# Altium schematic sheet 01_USB_B_and_Power.SchDoc(01_USB_B_and_Power)
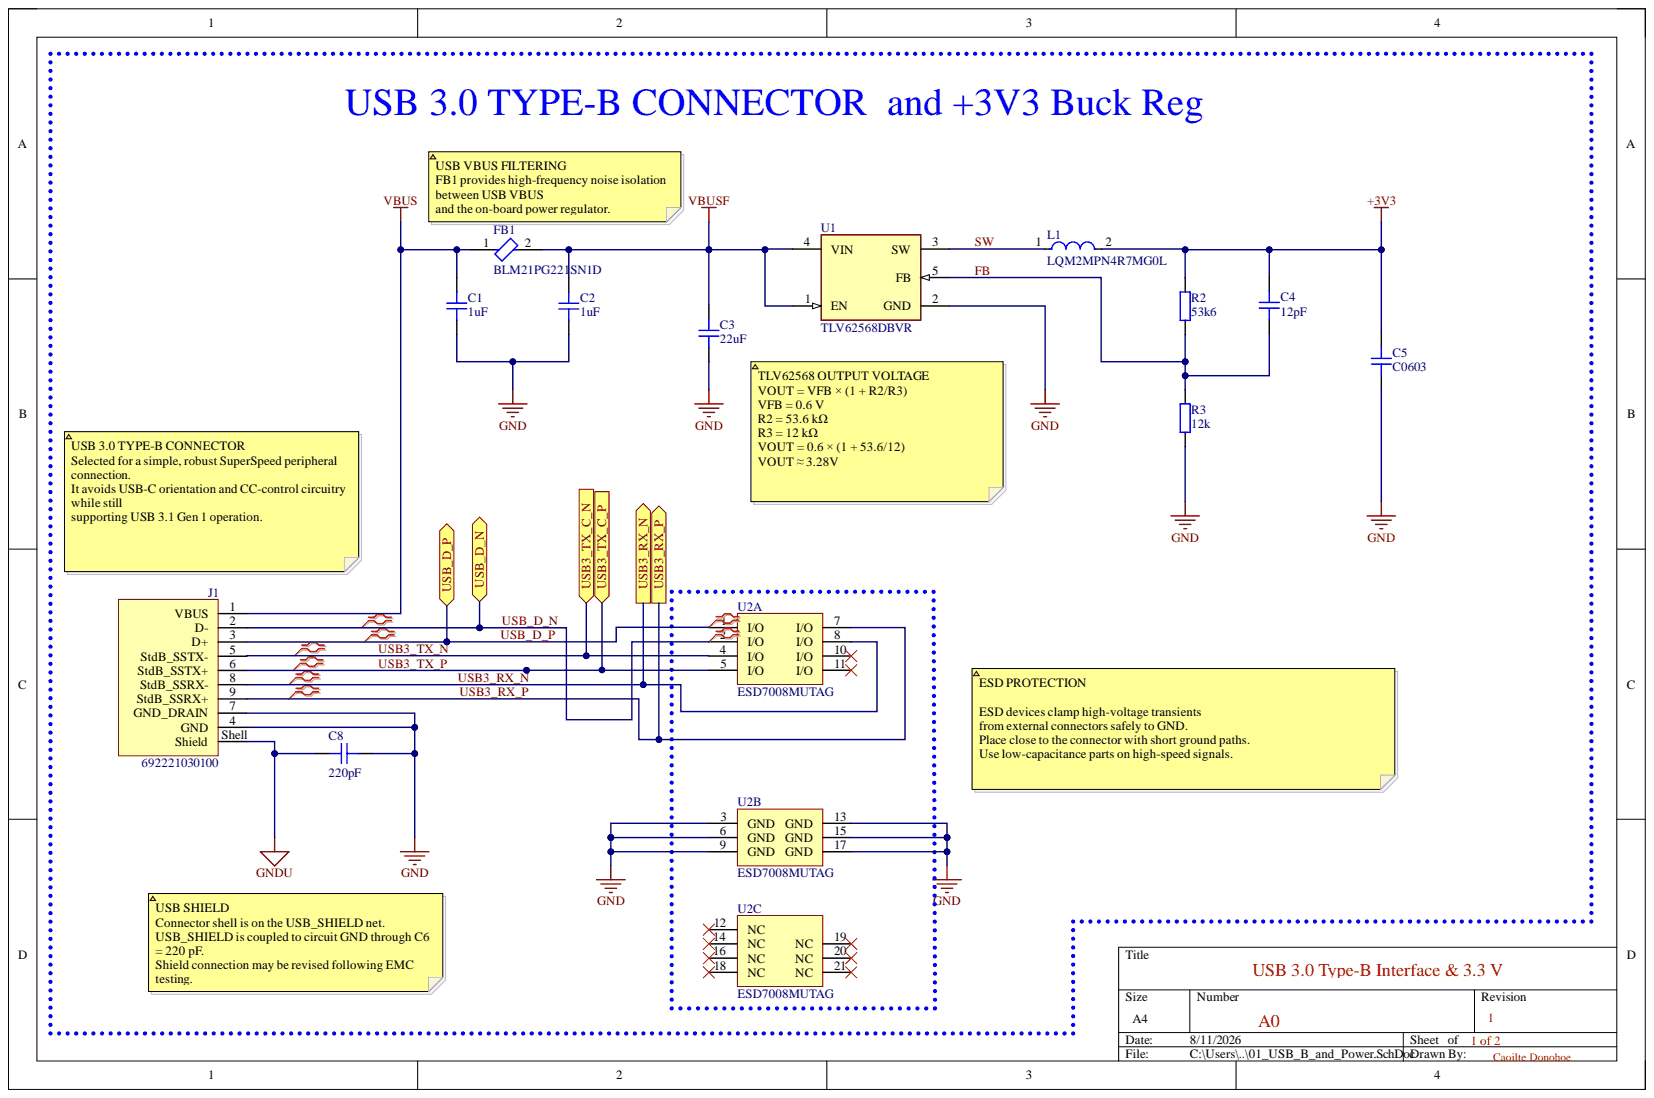
[diagram p0: 01_USB_B_and_Power.SchDoc]

## Parts
C1  1uF  [CAP_0402]
C2  1uF  [CAP_0402]
C3  22uF  [CAP_0805]
C4  12pF  [CAP_0402]
C5  C0603  [CAP_0603]
C8  220pF  [CAP_0603]
FB1  BLM21PG221SN1D  [FP-BLM21-0_2-t0_85-e0_5-IPC_C]
J1  692221030100  [692221030100]
L1  LQM2MPN4R7MG0L  [FP-LQM2MPN_G0-IPC_B]
R2  53k6  [RES_0402]
R3  12k  [RES_0402]
U1  TLV62568DBVR  [FP-DBV0005A-MFG]
U2  ESD7008MUTAG  [ONSC-UDFN-18-517BV_V]  (parts A,B,C)

## Ports
USB3_RX_N, USB3_RX_P, USB3_TX_C_N, USB3_TX_C_P, USB_D_N, USB_D_P

## Nets
+3V3: C4.2 C5.2 L1.2 R2.2
GND: C1.1 C2.1 C3.1 C5.1 C8.2 J1.4(GND) J1.7(GND_DRAIN) R3.1 U1.2(GND) U2.3(GND) U2.6(GND) U2.9(GND) U2.13(GND) U2.15(GND) U2.17(GND)
GNDU: C8.1 J1.Shell(Shield)
VBUS: C1.2 FB1.1 J1.1(VBUS)
VBUSF: C2.2 C3.2 FB1.2 U1.1(EN) U1.4(VIN)
FB: C4.1 R2.1 R3.2 U1.5(FB)
SW: L1.1 U1.3(SW)
USB3_RX_N: J1.8(StdB_SSRX-) U2.8(I/O) ; port USB3_RX_N
USB3_RX_P: J1.9(StdB_SSRX+) U2.7(I/O) ; port USB3_RX_P
USB3_TX_N: J1.5(StdB_SSTX-) U2.4(I/O) ; port USB3_TX_C_N
USB3_TX_P: J1.6(StdB_SSTX+) U2.5(I/O) ; port USB3_TX_C_P
USB_D_N: J1.2(D-) U2.2(I/O) ; port USB_D_N
USB_D_P: J1.3(D+) U2.1(I/O) ; port USB_D_P

Not connected: U2 10(I/O) 11(I/O) 12(NC) 14(NC) 16(NC) 18(NC) 19(NC) 20(NC) 21(NC)

## Library data of the parts
C1 C2 C4 = CAP_0402: Capacitor SMD 0402; Component Kind: Standard; Library Name: Schematic-Lib-PMS_Caoilte.SchLib
C3 = CAP_0805: Capacitor SMD 0805; Component Kind: Standard; Library Name: Schematic-Lib-PMS_Caoilte.SchLib
C5 C8 = CAP_0603: Capacitor SMD 0603; Component Kind: Standard; Library Name: Schematic-Lib-PMS_Caoilte.SchLib
FB1 = CMP-0686-00610-3: Chip Ferrite Bead, 0805, 220Ω @ 100MHz, 0.045Ω, 25%, 2A; DC Resistance (DCR): 45mR; Impedance: 220R; Number of Circuits: 1; Shielding: Unshielded; Case Code (Imperial): 0805; Case Code (Metric): 2012; Case/Package: 0805; Component Kind: Standard; Contact Plating: Tin; Core Material: Ferrite; Current: 2A; Current Rating: 2A; DC Current: 2A; DC Resistance-Max: 45mR; Depth: 1.25mm; Frequency: 100MHz; Height: 0.85mm; Lead Free: Lead Free; Length: 2mm; Library Name: LAN7800_USB_Ethernet_RevA.SchLib; Material: Ferrite; Max Current Rating: 2A; Max DC Current: 2A; Max Operating Temperature: 125°C; Military Standard: Not; Min Operating Temperature: -55°C; Number of Lines: 1; Number of Pins: 2; Package Quantity: 1; Packaging: Tape and Reel; Radiation Hardening: No; REACH SVHC: No SVHC; RoHS Compliant: Yes; Series: BLM21P; Termination: SMD/SMT; Test Frequency: 100MHz; Tolerance: 25%; Width: 1.25mm
J1 = CMP-1502-03224-3: Conn USB 3.0 Type B RCP 9 POS (1.75mm/2.5)mm/1.25mm Solder RA Thru-Hole 9 Terminal 1 Port Tray; Contact Resistance: 50mΩ; Current Rating: 250mA; Gender: Receptacle; Insulation Resistance: 100MΩ; Number of Contacts: 9; Number of Ports: 1; Operating Supply Voltage: 30V; USB Standard: USB 3.0; Color: Blue; Component Kind: Standard; Connector Type: USB; Contact Material: Nickel, Tin; Contact Plating: Gold; Features: Shielded; Flammability Rating: UL94 V-0; Height: 13.05mm; Lead Free: Lead Free; Length: 12mm; Library Name: LAN7800_USB_Ethernet_RevA.SchLib; Max Operating Temperature: 85°C; Min Operating Temperature: -20°C; Mount: Horizontal, PCB, Through Hole; Number of Pins: 9; Orientation: Horizontal; RoHS: Compliant; Termination: Through Hole; Voltage Rating: 30V; Voltage Rating (AC): 30V; Width: 18.3mm
L1 = CMP-06042-016483-1: Multilayer Type Inductor for Power Lines, 0806 (2016), 4.7μH, 20%, 0.175Ω, 1.1A; Core Material: Ferrite; DC Resistance (DCR): 175mR; Inductance: 4.7uH; Self Resonant Frequency: 20MHz; Shielding: Shielded; Test Frequency: 1MHz; Case Code (Imperial): 0806; Case Code (Metric): 2016; Case/Package: 0805; Component Kind: Standard; Current Rating: 1.1A; DC Current: 1.1A; Depth: 1.75mm; Height: 0.9mm; Height - Seated (Max): 0.039inch; Length: 0.079inch; Library Name: LAN7800_USB_Ethernet_RevA.SchLib; Max DC Current: 1.1A; Max Operating Temperature: 125°C; Military Standard: Not; Min Operating Temperature: -55°C; Mount: Surface Mount; Package Quantity: 3000; Packaging: Tape and Reel; RoHS Compliant: Yes; Termination: SMD/SMT; Tolerance: 20%; Weight: 0.000353oz; Width: 0.049inch
R2 R3 = RES_0402: Resistor SMD 0402; Component Kind: Standard; Library Name: Schematic-Lib-PMS_Caoilte.SchLib
U1 = CMP-04918-000009-1: IC REG BUCK ADJ 1A SOT23-5; Manufacturer: Texas Instruments; Max Duty Cycle: 100%; Max Junction Temp: +125°C; Max Supply Voltage: 5.5V; Min Supply Voltage: 2.5V; Nominal Supply Current: 35uA; Number Of Outputs: 1; Output Type: AdjustableProgrammable; Output Voltage: 0.6-5.5V; Standoff Height: 0mm; Switching Frequency: 1.5MHz; Automotive: No; Category: IC; Component Kind: Standard; ComponentLink2Description: Datasheet; ComponentLink2URL: http://www.ti.com/lit/ds/symlink/tlv62568.pdf; Device Class L1: Integrated Circuits (ICs); Device Class L2: Power Management ICs; Device Class L3: Voltage Regulators - Switching; Digikey Description: IC REG BUCK ADJ 1A SOT23-5; Height: 1.45mm; Lead Free: Yes; Library Name: LAN7800_USB_Ethernet_RevA.SchLib; Manufacturer Part Number: TLV62568DBVR; Mouser Description: 1-A High Efficiency Synchronous Buck Converter, SMD, 5-pin SOT-23, T/R 3k; Octopart: https://octopart.com/tlv62568dbvr-texas+instruments-76926199; Output Current: 1A; Package: DBV0005A; RoHS: TRUE; Switching Topology: Buck; Temperature: -40°C to +125°C; Temperature Range High: +125°C; Temperature Range Low: -40°C
U2 = CMP-1063-00247-1: Transient Voltage Suppressor, Low Capacitance ESD Protection for High Speed Data, 18-Pin UDFN, Pb-Free, Tape and Reel; Manufacturer: On Semiconductor; Direction: Bidirectional; IR Max (uA): 1; Number of Lines: 8; V(BR) Min (V): 5.5; VRWM Max (V): 5; Component Kind: Standard; ComponentLink1Description: Manufacturer URL; ComponentLink1URL: http://www.onsemi.com/; ComponentLink2Description: Datasheet; ComponentLink2URL: http://www.onsemi.cn/pub_link/Collateral/ESD7008-D.PDF; ComponentLink3Description: Package Specification; ComponentLink3URL: http://www.onsemi.cn/pub_link/Collateral/517BV.PDF; DatasheetVersion: Rev. 4; Library Name: LAN7800_USB_Ethernet_RevA.SchLib; Mounting Technology: Surface Mount; PackageDescription: 18-Pin Small Outline No-Lead, Body 5.5 x 1.5 mm; PackageReference: UDFN-18-517BV; PackageVersion: Rev. A, 12/2012; Packing: Tape and Reel; PartNumber: ESD7008MUTAG; RoHS: Pb-Free
